# Altium schematic sheet HDMI.SchDoc
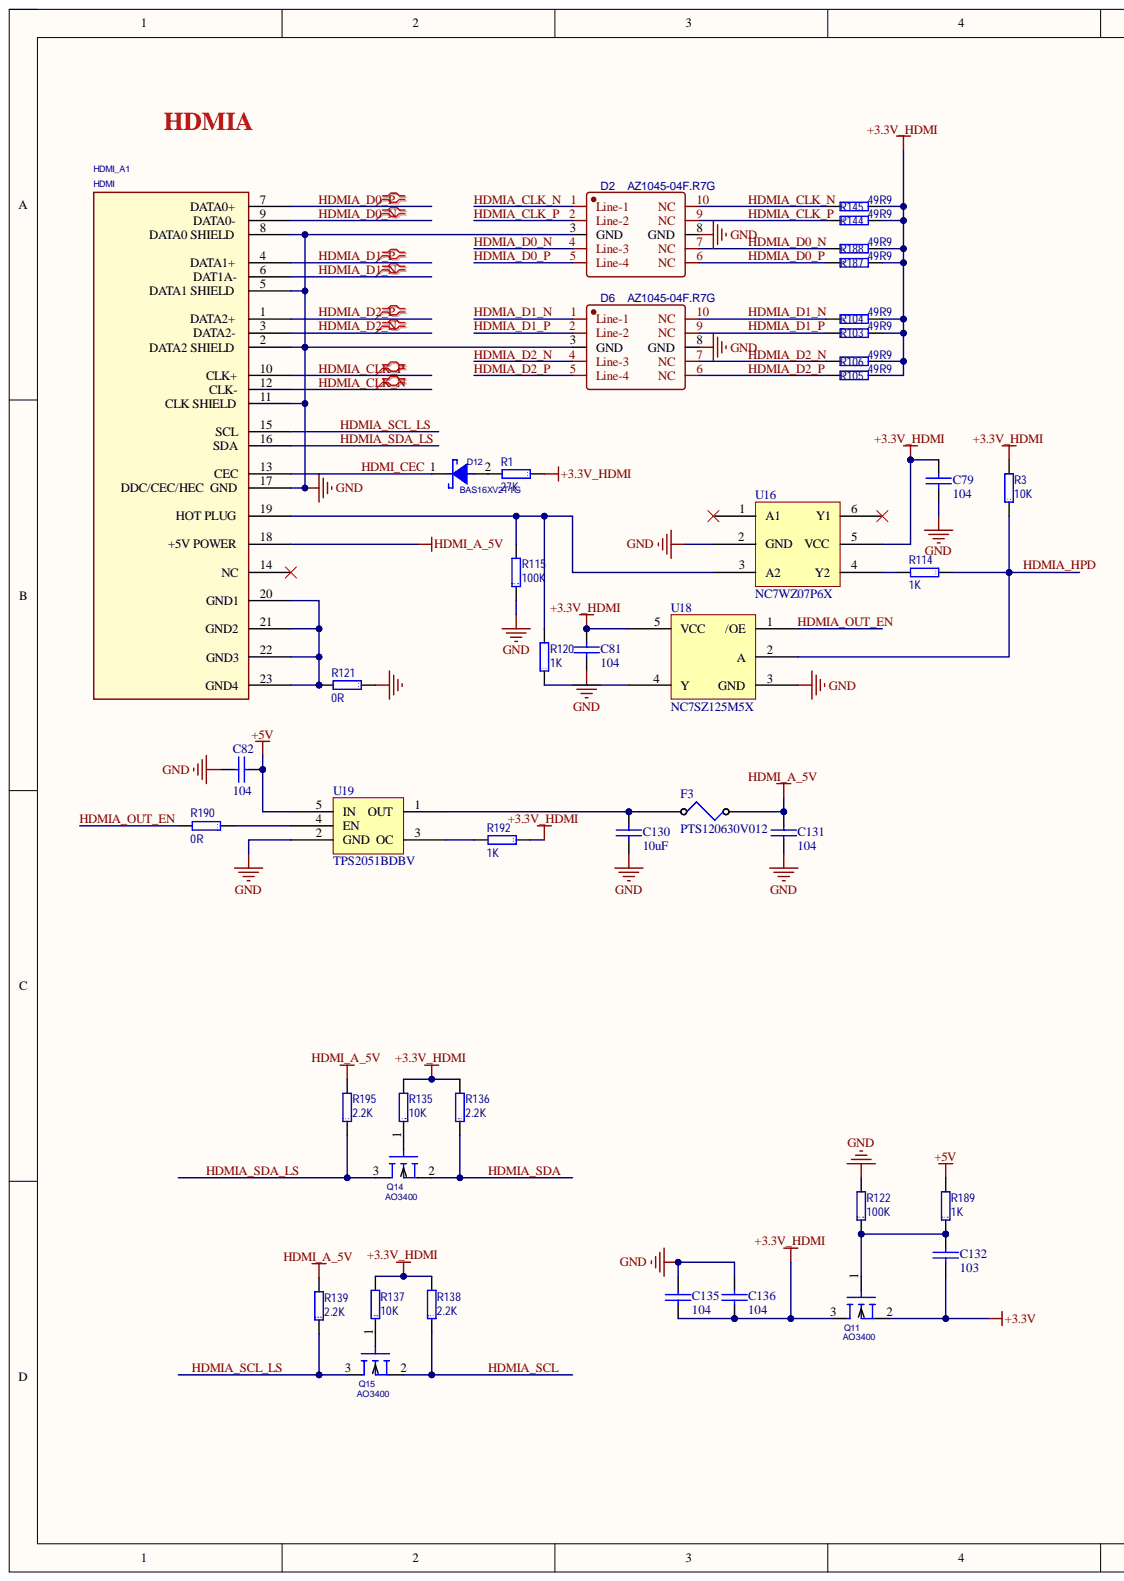
[diagram p0: HDMI.SchDoc, left]
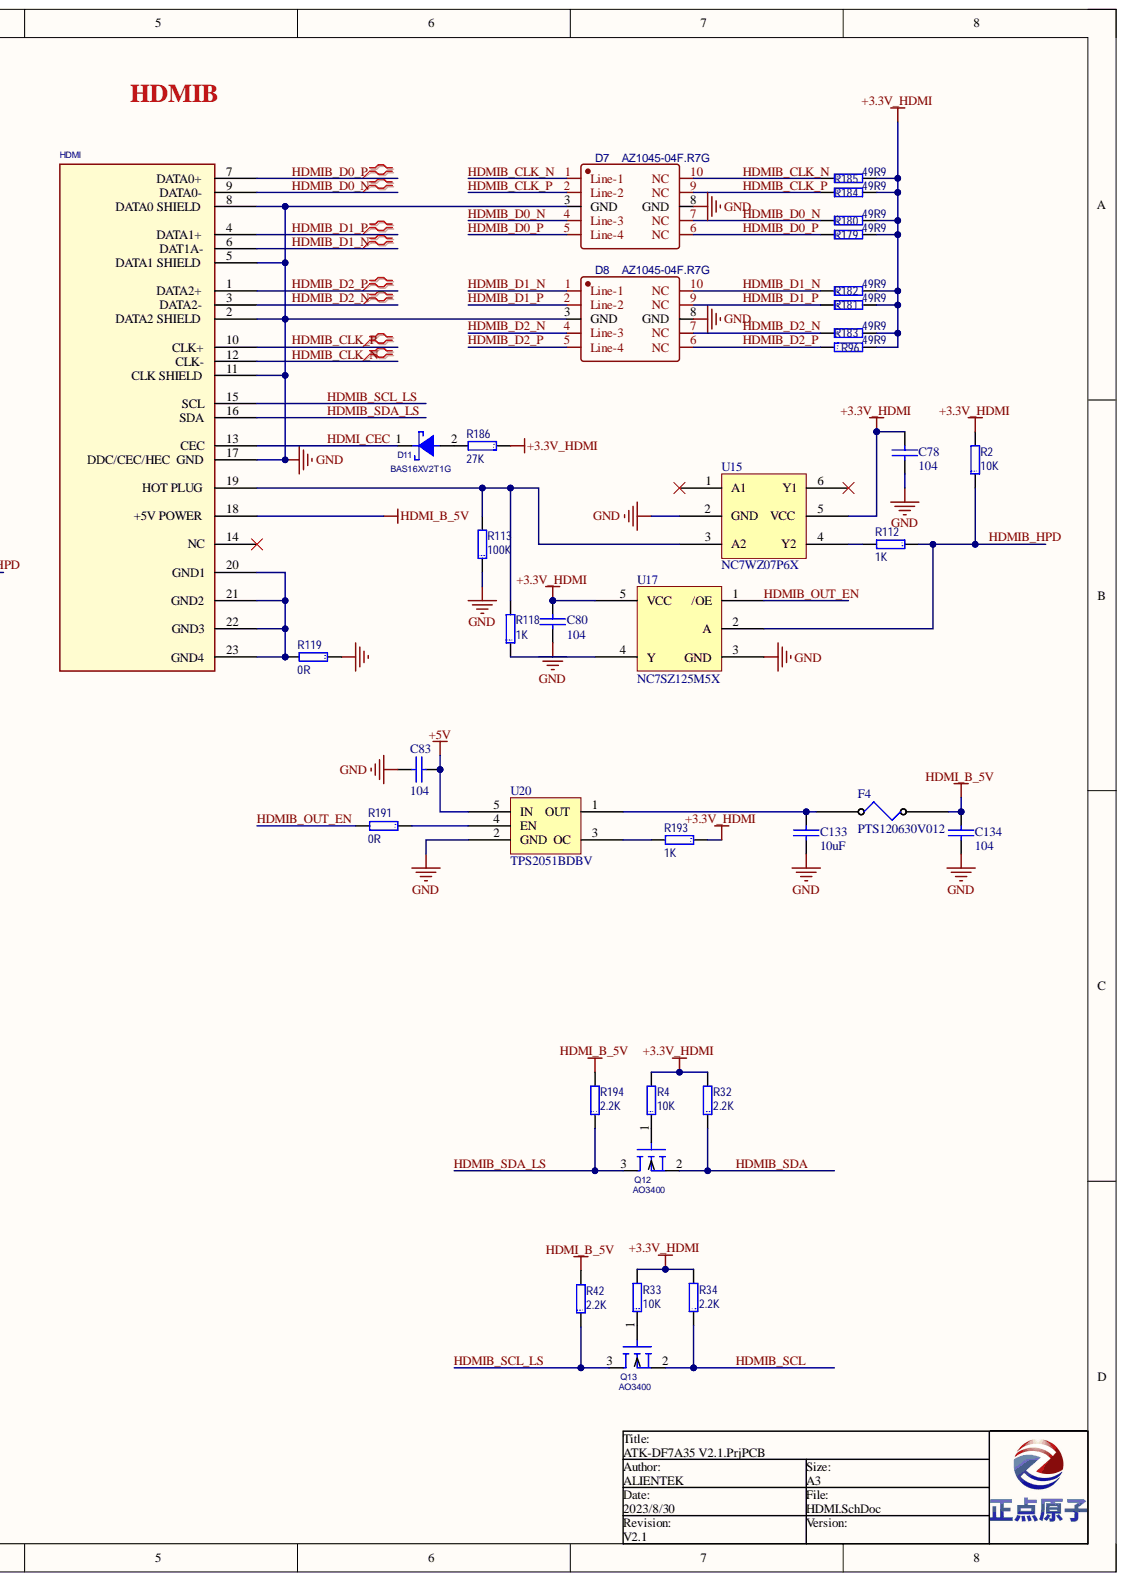
[diagram p1: HDMI.SchDoc, right]

## Parts
C78  104  [0603P]
C79  104  [0603P]
C80  104  [0603P]
C81  104  [0603P]
C82  104  [0603P]
C83  104  [0603P]
C130  10uF  [0603P]
C131  104  [0603P]
C132  103  [0603P]
C133  10uF  [0603P]
C134  104  [0603P]
C135  104  [0603P]
C136  104  [0603P]
D2  AZ1045-04F.R7G  [DFN2510P10E]
D6  AZ1045-04F.R7G  [DFN2510P10E]
D7  AZ1045-04F.R7G  [DFN2510P10E]
D8  AZ1045-04F.R7G  [DFN2510P10E]
D11  BAS16XV2T1G  [BAS16XV2T1G]
D12  BAS16XV2T1G  [BAS16XV2T1G]
F3  PTS120630V012  [FU 1206]
F4  PTS120630V012  [FU 1206]
HDMI_A1  HDMI  [HDMI_SMD_A]
HDMI_B1  HDMI  [HDMI_SMD_A]
Q11  AO3400  [SOT23]
Q12  AO3400  [SOT23]
Q13  AO3400  [SOT23]
Q14  AO3400  [SOT23]
Q15  AO3400  [SOT23]
R1  27K  [0603P]
R2  10K  [0603P]
R3  10K  [0603P]
R4  10K  [0603P]
R32  2.2K  [0603P]
R33  10K  [0603P]
R34  2.2K  [0603P]
R42  2.2K  [0603P]
R96  49R9  [0603P]
R103  49R9  [0603P]
R104  49R9  [0603P]
R105  49R9  [0603P]
R106  49R9  [0603P]
R112  1K  [0603P]
R113  100K  [0603P]
R114  1K  [0603P]
R115  100K  [0603P]
R118  1K  [0603P]
R119  0R  [0603P]
R120  1K  [0603P]
R121  0R  [0603P]
R122  100K  [0603P]
R135  10K  [0603P]
R136  2.2K  [0603P]
R137  10K  [0603P]
R138  2.2K  [0603P]
R139  2.2K  [0603P]
R144  49R9  [0603P]
R145  49R9  [0603P]
R179  49R9  [0603P]
R180  49R9  [0603P]
R181  49R9  [0603P]
R182  49R9  [0603P]
R183  49R9  [0603P]
R184  49R9  [0603P]
R185  49R9  [0603P]
R186  27K  [0603P]
R187  49R9  [0603P]
R188  49R9  [0603P]
R189  1K  [0603P]
R190  0R  [0603P]
R191  0R  [0603P]
R192  1K  [0603P]
R193  1K  [0603P]
R194  2.2K  [0603P]
R195  2.2K  [0603P]
U15  NC7WZ07P6X  [NC7WZ07P6X]
U16  NC7WZ07P6X  [NC7WZ07P6X]
U17  NC7SZ125M5X  [NC7SZ125M5X]
U18  NC7SZ125M5X  [NC7SZ125M5X]
U19  TPS2051BDBV  [TPS2051BDBV]
U20  TPS2051BDBV  [TPS2051BDBV]

## Nets
+3.3V: C132.2 Q11.2
+3.3V_HDMI: C78.1 C79.1 C80.1 C81.1 C135.2 C136.2 Q11.3 R1.1 R2.2 R3.2 R4.2 R32.2 R33.2 R34.2 R96.2 R103.2 R104.2 R105.2 R106.2 R135.2 R136.2 R137.2 R138.2 R144.2 R145.2 R179.2 R180.2 R181.2 R182.2 R183.2 R184.2 R185.2 R186.1 R187.2 R188.2 R192.1 R193.1 U15.5(VCC) U16.5(VCC) U17.5(VCC) U18.5(VCC)
+5V: C82.1 C83.1 R189.2 U19.5(IN) U20.5(IN)
GND: C78.2 C79.2 C80.2 C81.2 C82.2 C83.2 C130.2 C131.2 C133.2 C134.2 C135.1 C136.1 D2.3(GND) D2.8(GND) D6.3(GND) D6.8(GND) D7.3(GND) D7.8(GND) D8.3(GND) D8.8(GND) HDMI_A1.2(DATA2_SHIELD) HDMI_A1.5(DATA1_SHIELD) HDMI_A1.8(DATA0_SHIELD) HDMI_A1.11(CLK_SHIELD) HDMI_A1.17(GND) HDMI_B1.2(DATA2_SHIELD) HDMI_B1.5(DATA1_SHIELD) HDMI_B1.8(DATA0_SHIELD) HDMI_B1.11(CLK_SHIELD) HDMI_B1.17(GND) R113.1 R115.1 R119.1 R121.1 R122.2 U15.2(GND) U16.2(GND) U17.3(GND) U18.3(GND) U19.2(GND) U20.2(GND)
HDMI_A_5V: C131.1 F3.2 HDMI_A1.18(+5V_POWER) R139.2 R195.2
HDMI_B_5V: C134.1 F4.2 HDMI_B1.18(+5V_POWER) R42.2 R194.2
HDMI_CEC: D11.1 D12.1 HDMI_A1.13(CEC) HDMI_B1.13(CEC)
HDMIA_CLK_N: D2.1(Line-1) D2.10(NC) HDMI_A1.12(CLK-) R145.1
HDMIA_CLK_P: D2.2(Line-2) D2.9(NC) HDMI_A1.10(CLK+) R144.1
HDMIA_D0_N: D2.4(Line-3) D2.7(NC) HDMI_A1.9(DATA0-) R188.1
HDMIA_D0_P: D2.5(Line-4) D2.6(NC) HDMI_A1.7(DATA0+) R187.1
HDMIA_D1_N: D6.1(Line-1) D6.10(NC) HDMI_A1.6(DAT1A-) R104.1
HDMIA_D1_P: D6.2(Line-2) D6.9(NC) HDMI_A1.4(DATA1+) R103.1
HDMIA_D2_N: D6.4(Line-3) D6.7(NC) HDMI_A1.3(DATA2-) R106.1
HDMIA_D2_P: D6.5(Line-4) D6.6(NC) HDMI_A1.1(DATA2+) R105.1
HDMIA_HPD: R3.1 R114.1 U18.2(A)
HDMIA_OUT_EN: R190.2 U18.1(/OE)
HDMIA_SCL: Q15.2 R138.1
HDMIA_SCL_LS: HDMI_A1.15(SCL) Q15.3 R139.1
HDMIA_SDA: Q14.2 R136.1
HDMIA_SDA_LS: HDMI_A1.16(SDA) Q14.3 R195.1
HDMIB_CLK_N: D7.1(Line-1) D7.10(NC) HDMI_B1.12(CLK-) R185.1
HDMIB_CLK_P: D7.2(Line-2) D7.9(NC) HDMI_B1.10(CLK+) R184.1
HDMIB_D0_N: D7.4(Line-3) D7.7(NC) HDMI_B1.9(DATA0-) R180.1
HDMIB_D0_P: D7.5(Line-4) D7.6(NC) HDMI_B1.7(DATA0+) R179.1
HDMIB_D1_N: D8.1(Line-1) D8.10(NC) HDMI_B1.6(DAT1A-) R182.1
HDMIB_D1_P: D8.2(Line-2) D8.9(NC) HDMI_B1.4(DATA1+) R181.1
HDMIB_D2_N: D8.4(Line-3) D8.7(NC) HDMI_B1.3(DATA2-) R183.1
HDMIB_D2_P: D8.5(Line-4) D8.6(NC) HDMI_B1.1(DATA2+) R96.1
HDMIB_HPD: R2.1 R112.1 U17.2(A)
HDMIB_OUT_EN: R191.2 U17.1(/OE)
HDMIB_SCL: Q13.2 R34.1
HDMIB_SCL_LS: HDMI_B1.15(SCL) Q13.3 R42.1
HDMIB_SDA: Q12.2 R32.1
HDMIB_SDA_LS: HDMI_B1.16(SDA) Q12.3 R194.1
NetC130_1: C130.1 F3.1 U19.1(OUT)
NetC132_1: C132.1 Q11.1 R122.1 R189.1
NetC133_1: C133.1 F4.1 U20.1(OUT)
NetD11_2: D11.2 R186.2
NetD12_2: D12.2 R1.2
NetHDMI_A1_19: HDMI_A1.19(HOT_PLUG) R115.2 R120.2 U16.3(A2)
NetHDMI_A1_20: HDMI_A1.20(GND1) HDMI_A1.21(GND2) HDMI_A1.22(GND3) HDMI_A1.23(GND4) R121.2
NetHDMI_B1_19: HDMI_B1.19(HOT_PLUG) R113.2 R118.2 U15.3(A2)
NetHDMI_B1_20: HDMI_B1.20(GND1) HDMI_B1.21(GND2) HDMI_B1.22(GND3) HDMI_B1.23(GND4) R119.2
NetQ12_1: Q12.1 R4.1
NetQ13_1: Q13.1 R33.1
NetQ14_1: Q14.1 R135.1
NetQ15_1: Q15.1 R137.1
NetR112_2: R112.2 U15.4(Y2)
NetR114_2: R114.2 U16.4(Y2)
NetR118_1: R118.1 U17.4(Y)
NetR120_1: R120.1 U18.4(Y)
NetR190_1: R190.1 U19.4(EN)
NetR191_1: R191.1 U20.4(EN)
NetR192_2: R192.2 U19.3(OC)
NetR193_2: R193.2 U20.3(OC)

Not connected: HDMI_A1 14(NC); HDMI_B1 14(NC); U15 1(A1) 6(Y1); U16 1(A1) 6(Y1)

## Library data of the parts
C78-C83 C131 C132 C134-C136 = CAP_104_0.1uf: Component Kind: Standard; Library Name: ZYNQ.SCHLIB
C130 C133 = CAP: Component Kind: Standard; Library Name: ZYNQ.SCHLIB
D2 D6-D8 = AZ1045-04F.R7G: BOM_Manufacturer: AMAZING; Contributor: LCSC; BOM_Manufacturer Part: AZ1045-04F.R7G; BOM_Supplier: LCSC; BOM_Supplier Part: C356811; Component Kind: Standard; spicePre: D; spiceSymbolName: AZ1045-04F.R7G
D11 D12 = 1N5817: Component Kind: Standard; Library Name: ZYNQ.SCHLIB
HDMI_A1 HDMI_B1 = HDMI_A: Component Kind: Standard
Q11-Q15 = AO3400: PSpiceTemplate: X^@REFDES %d %g %s @MODEL; Component Kind: Standard; Library Name: ZYNQ.SCHLIB; Simulation: AO3400
R1-R4 R32-R34 R42 R96 R103-R106 R112-R115 R118-R122 R135-R139 R144 R145 R179-R195 = RES: Component Kind: Standard; Library Name: ZYNQ.SCHLIB
F3 F4 = PTS120630V012: Component Kind: Standard; Library Name: ZYNQ.SCHLIB
U15 U16 = NC7WZ07P6X: Component Kind: Standard; Library Name: ZYNQ.SCHLIB
U17 U18 = NC7SZ125M5X: Component Kind: Standard; Library Name: ZYNQ.SCHLIB
U19 U20 = TPS2051BDBV: Component Kind: Standard; Library Name: ZYNQ.SCHLIB
